# Altium schematic sheet ESP32_H2.SchDoc
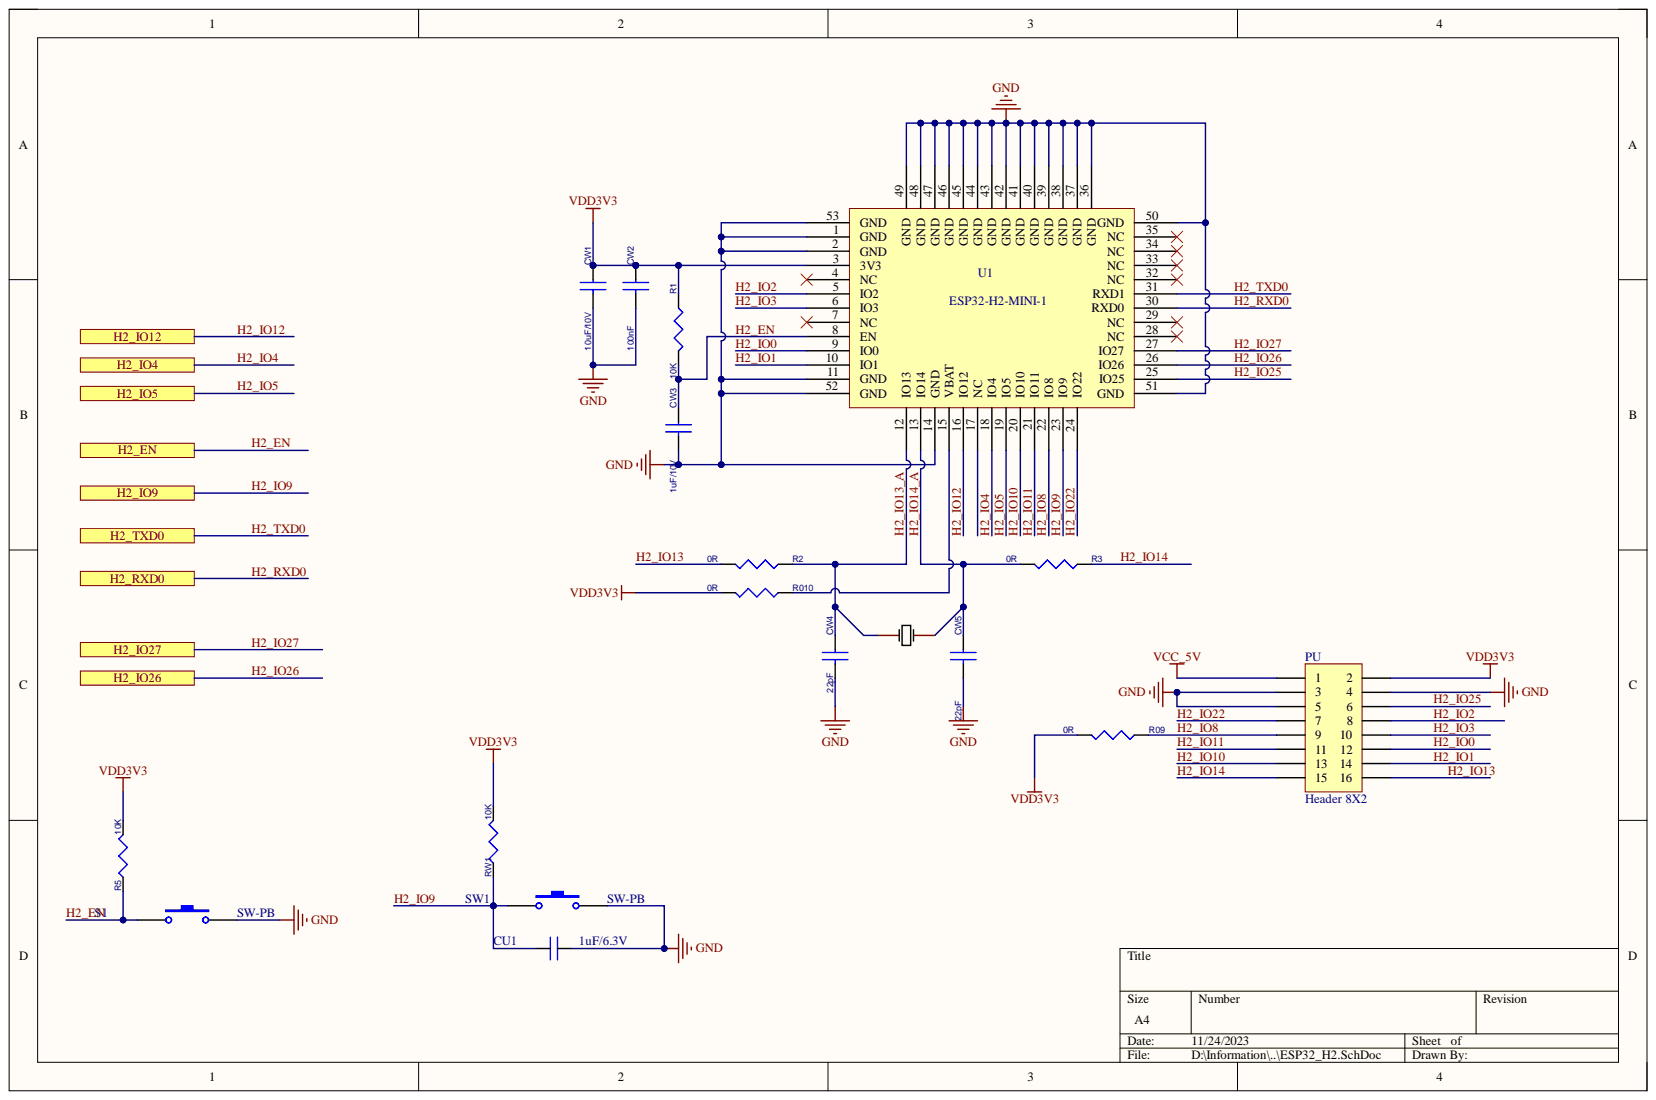
[diagram p0: ESP32_H2.SchDoc]

## Parts
CU1  1uF/6.3V  [LC-0402_C]
CW1  10uF/10V  [LC-0805_C]
CW2  100nF  [LC-0603_C]
CW3  1uF/10V  [LC-0402_C]
CW4  22pF  [LC-0402_C]
CW5  22pF  [LC-0402_C]
PU  Header 8X2  [HDR-SMD_16P-P1.27-V-M-R2-C8-LS4.5]
R1  10K  [LC-0402_R]
R2  0R  [LC-0402_R]
R3  0R  [LC-0402_R]
R5  10K  [LC-0402_R]
R09  0R  [LC-0402_R]
R010  0R  [LC-0402_R]
RW1  10K  [LC-0402_R]
S1  SW-PB  [KEY--4P]
SW1  SW-PB  [KEY--4P]
U1  ESP32-H2-MINI-1  [WIFIM-SMD_ESP32-C3-MINI-1]
X1  TFX-03-32.768K  [CRYSTAL-SMD_L2.0-W1.2]  (value not drawn)

## Ports
H2_EN, H2_IO4, H2_IO5, H2_IO9, H2_IO12, H2_IO26, H2_IO27, H2_RXD0, H2_TXD0

## Nets
GND: CU1.1 CW1.2 CW2.2 CW3.2 CW4.2 CW5.2 PU.3 PU.4 PU.5 S1.2 SW1.2 U1.1(GND) U1.2(GND) U1.11(GND) U1.14(GND) U1.36(GND) U1.37(GND) U1.38(GND) U1.39(GND) U1.40(GND) U1.41(GND) U1.42(GND) U1.43(GND) U1.44(GND) U1.45(GND) U1.46(GND) U1.47(GND) U1.48(GND) U1.49(GND) U1.50(GND) U1.51(GND) U1.52(GND) U1.53(GND)
VCC_5V: PU.1
VDD3V3: CW1.1 CW2.1 PU.2 R1.1 R5.2 R09.2 R010.2 RW1.2 U1.3(3V3)
H2_EN: CW3.1 R1.2 R5.1 S1.1 U1.8(EN) ; port H2_EN
H2_IO0: PU.12 U1.9(IO0)
H2_IO1: PU.14 U1.10(IO1)
H2_IO2: PU.8 U1.5(IO2)
H2_IO3: PU.10 U1.6(IO3)
H2_IO4: U1.18(IO4) ; port H2_IO4
H2_IO5: U1.19(IO5) ; port H2_IO5
H2_IO8: PU.9 R09.1 U1.22(IO8)
H2_IO9: CU1.2 RW1.1 SW1.1 U1.23(IO9) ; port H2_IO9
H2_IO10: PU.13 U1.20(IO10)
H2_IO11: PU.11 U1.21(IO11)
H2_IO12: U1.16(IO12) ; port H2_IO12
H2_IO13: PU.16 R2.2
H2_IO13_A: CW4.1 R2.1 U1.12(IO13) X1.1
H2_IO14: PU.15 R3.1
H2_IO14_A: CW5.1 R3.2 U1.13(IO14) X1.2
H2_IO22: PU.7 U1.24(IO22)
H2_IO25: PU.6 U1.25(IO25)
H2_IO26: U1.26(IO26) ; port H2_IO26
H2_IO27: U1.27(IO27) ; port H2_IO27
H2_RXD0: U1.30(RXD0) ; port H2_RXD0
H2_TXD0: U1.31(RXD1) ; port H2_TXD0
NetR010_1: R010.1 U1.15(VBAT)

Not connected: U1 4(NC) 7(NC) 17(NC) 28(NC) 29(NC) 32(NC) 33(NC) 34(NC) 35(NC)

## Library data of the parts
CU1 = C: Component Kind: Standard; Library Name: 光学蓝牙.SCHLIB
CW1-CW5 = CAP NP: Component Kind: Standard
PU = Header 8X2: Header, 8-Pin, Dual row; Component Kind: Standard; LatestRevisionDate: 17-Jul-2002; LatestRevisionNote: Re-released for DXP Platform.; Library Name: Miscellaneous Connectors.IntLib; Publisher: Altium Limited
R1-R3 R5 R09 R010 RW1 = R: Component Kind: Standard; Library Name: 光学蓝牙.SCHLIB
S1 SW1 = SW-PB: Switch; Component Kind: Standard; LatestRevisionDate: 17-Jul-2002; LatestRevisionNote: Re-released for DXP Platform.; Library Name: Miscellaneous Devices.IntLib; PackageReference: SPST-2; Published: 24-Mar-1999; Publisher: Altium Limited
X1 = TFX-03-32.768K: Manufacturer: RIVER(大河); Add into BOM: yes; Component Kind: Standard; Convert to PCB: yes; Crystal Type: -; Datasheet: https://atta.szlcsc.com/upload/public/pdf/source/20200604/C515343_41B8E3A268A234D1A6A113D698AA2603.pdf; Device: TFX-03-32.768K; JLCPCB Part Class: Extended Part; LCSC Part Name: 时钟晶体 32.768kHz 9PF 20PPM 停产; Load Capacitance: -; Manufacturer Part: TFX-03-32.768K; Name: -; Operating Temperature: -; Supplier: LCSC; Supplier Footprint: SMD2012-2P; Supplier Part: C515343; Symbol: TFX-03-32.768K; Value: -
U1 = ESP32-H2-MINI-1: Component Kind: Standard; Library Name: T_RGB.SCHLIB
